# Altium schematic sheet Face PCB Power & I2C.SchDoc
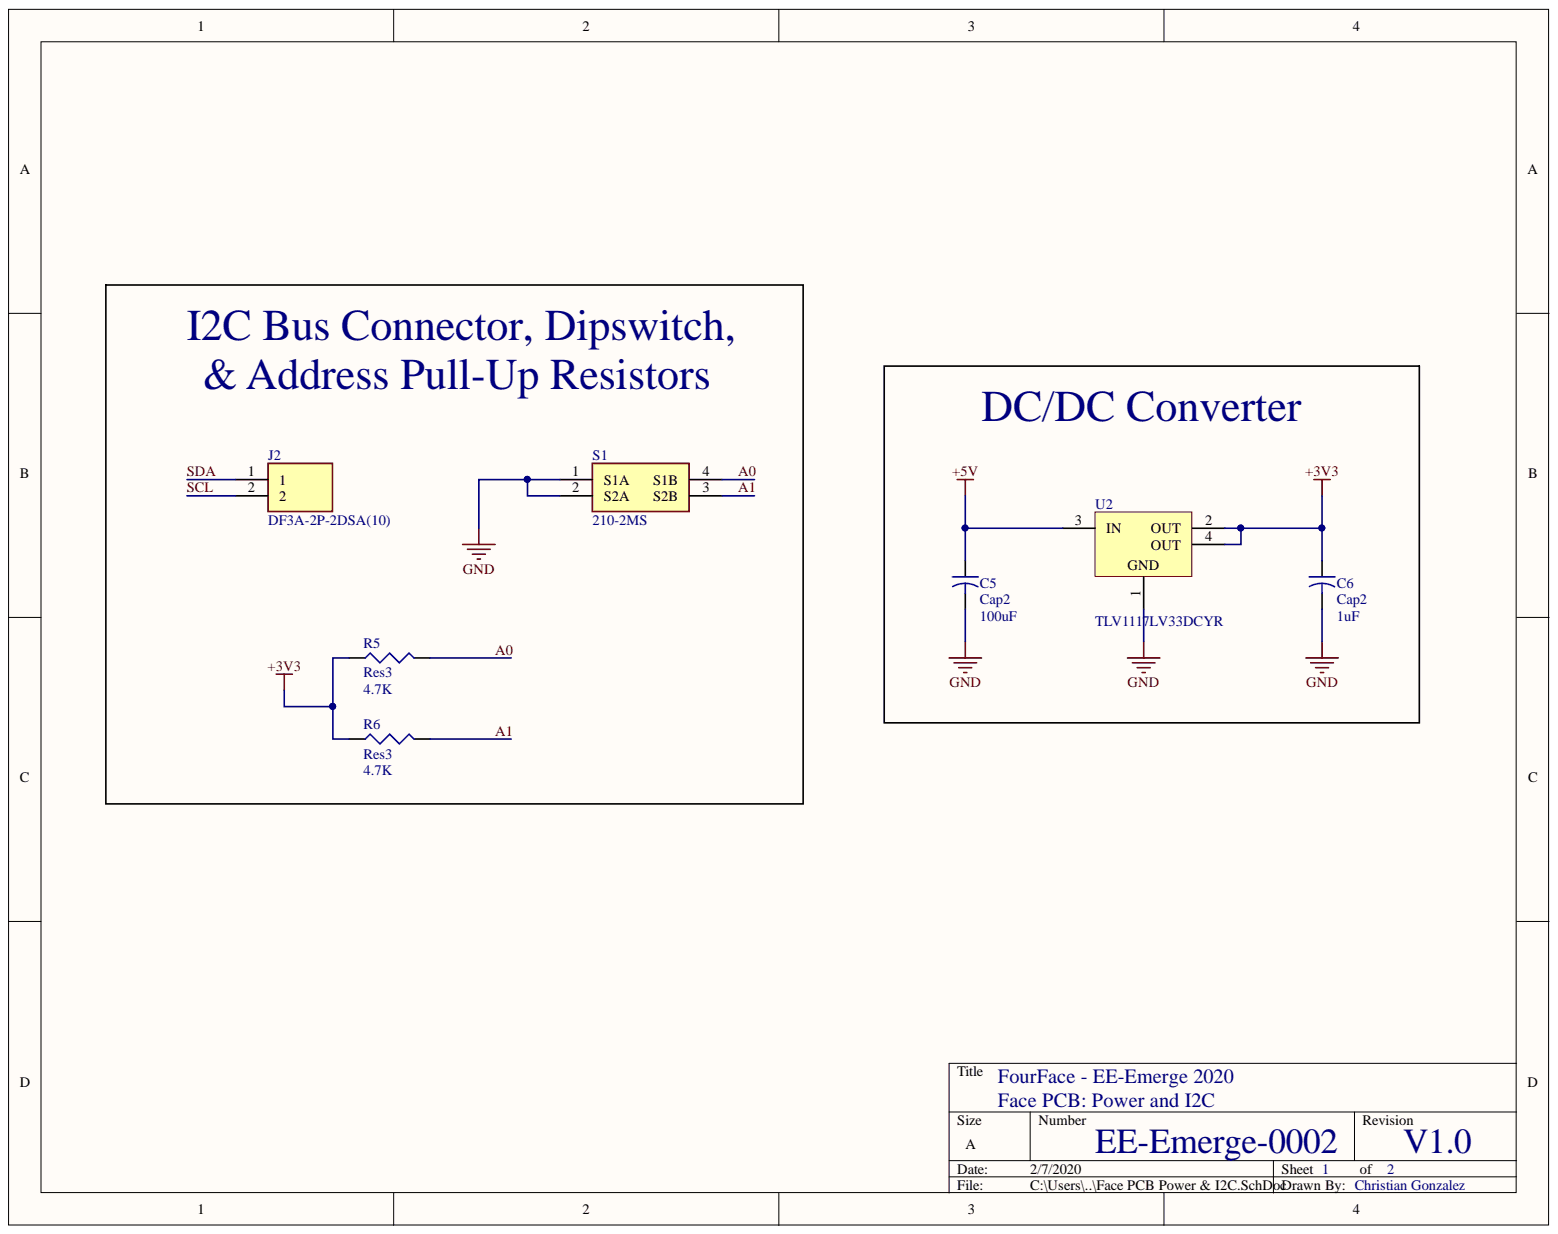
[diagram p0: Face PCB Power & I2C.SchDoc]

## Parts
C5  Cap2  value 100uF  [C0805]
C6  Cap2  value 1uF  [C0805]
J2  DF3A-2P-2DSA(10)  [SHDR2W50P0X200_1X2_600X500X500P]
R5  Res3  value 4.7K  [6-0805_N]
R6  Res3  value 4.7K  [6-0805_N]
S1  210-2MS  [DIP775W63P254L655H458Q4N]
U2  TLV1117LV33DCYR  [DCY0004A_N]

## Nets
+3V3: C6.1 R5.1 R6.1 U2.2(OUT) U2.4(OUT)
+5V: C5.1 U2.3(IN)
GND: C5.2 C6.2 S1.1(S1A) S1.2(S2A) U2.1
A0: R5.2 S1.4(S1B)
A1: R6.2 S1.3(S2B)
SCL: J2.2
SDA: J2.1

## Library data of the parts
C5 C6 = Cap2: Capacitor; Component Kind: Standard; Library Name: PCB_Project_2.IntLib; PackageDescription: Capacitor; 2 Leads; PackageReference: CAPR5-4X5; Published: 23-Sep-2002; Signal Integrity: Cap; Simulation: CAP; Publisher: Altium Limited
J2 = DF3A-2P-2DSA(10): Connector; Manufacturer_Name: Hirose; Component Kind: Standard; Datasheet Link: https://componentsearchengine.com/Datasheets/1/DF3A-2P-2DSA(10).pdf; Height: 5mm; Manufacturer_Part_Number: DF3A-2P-2DSA(10); Mouser Part Number: 798-DF3A-2P-2DSA10; Mouser Price/Stock: https://www.mouser.com/Search/Refine.aspx?Keyword=798-DF3A-2P-2DSA10
R5 R6 = Res3: Resistor; Component Kind: Standard; LatestRevisionDate: 29-May-2009; LatestRevisionNote: IPC-7351 Footprint Added.; PackageDescription: Chip Resistor; PackageReference: J1-0603; Published: 8-Jun-2000; Signal Integrity: Res; Simulation: RESISTOR; Library Name: EE_Emerge_SkillsProject.SCHLIB; Publisher: Altium Limited
S1 = 210-2MS: Switch; Manufacturer_Name: CTS; Component Kind: Standard; Datasheet Link: https://componentsearchengine.com/Datasheets/1/210-2MS.pdf; Height: 4.58mm; Manufacturer_Part_Number: 210-2MS; Mouser Part Number: 774-2102MS; Mouser Price/Stock: https://www.mouser.com/Search/Refine.aspx?Keyword=774-2102MS; RS Part Number: 1774261; RS Price/Stock: http://uk.rs-online.com/web/p/products/1774261
U2 = CMP-0395-00503-2: Single Output LDO, 1 A, Fixed 3.3 V Output, 2 to 5.5 V Input, 4-pin SOT-223 (DCY), -40 to 125 degC, Green (RoHS & no Sb/Br); Manufacturer: Texas Instruments; Accuracy(%): 2; Fixed Output Options(V): 3.3; Iout(Max)(A): 1; Iq(Typ)(mA): 0.05; SubFamily: Single Channel LDO; Vdo(Typ)(mV): 1200; Vin(Max)(V): 5.5; Code_JEDEC: TO-261; Component Kind: Standard; ComponentLink1Description: Manufacturer URL; ComponentLink1URL: http://www.ti.com/; ComponentLink2Description: Datasheet; ComponentLink2URL: http://www.ti.com/general/docs/lit/getliterature.tsp?genericPartNumber=TLV1117LV33&fileType=pdf; ComponentLink3Description: Package Specification; ComponentLink3URL: http://www.ti.com/litv/pdf/mpds094a; DatasheetVersion: SBVS160A; Eco-Plan: Green (RoHS & no Sb/Br); Library Name: Altium Content Vault; Mounting Technology: Surface Mount; PackageDescription: 4-Pin Plastic Small Outline, Body 3.5 x 6.5 mm, Pitch 2.3 mm; PackageReference: DCY0004A; PackageVersion: 4202506/B; PartNumber: TLV1117LV33DCYR; Vin(Min)(V): 2; Vout Adj(Max)(V): 3.3; Vout Adj(Min)(V): 3.3
